# Altium schematic sheet Sheet1.SchDoc(Sheet1)
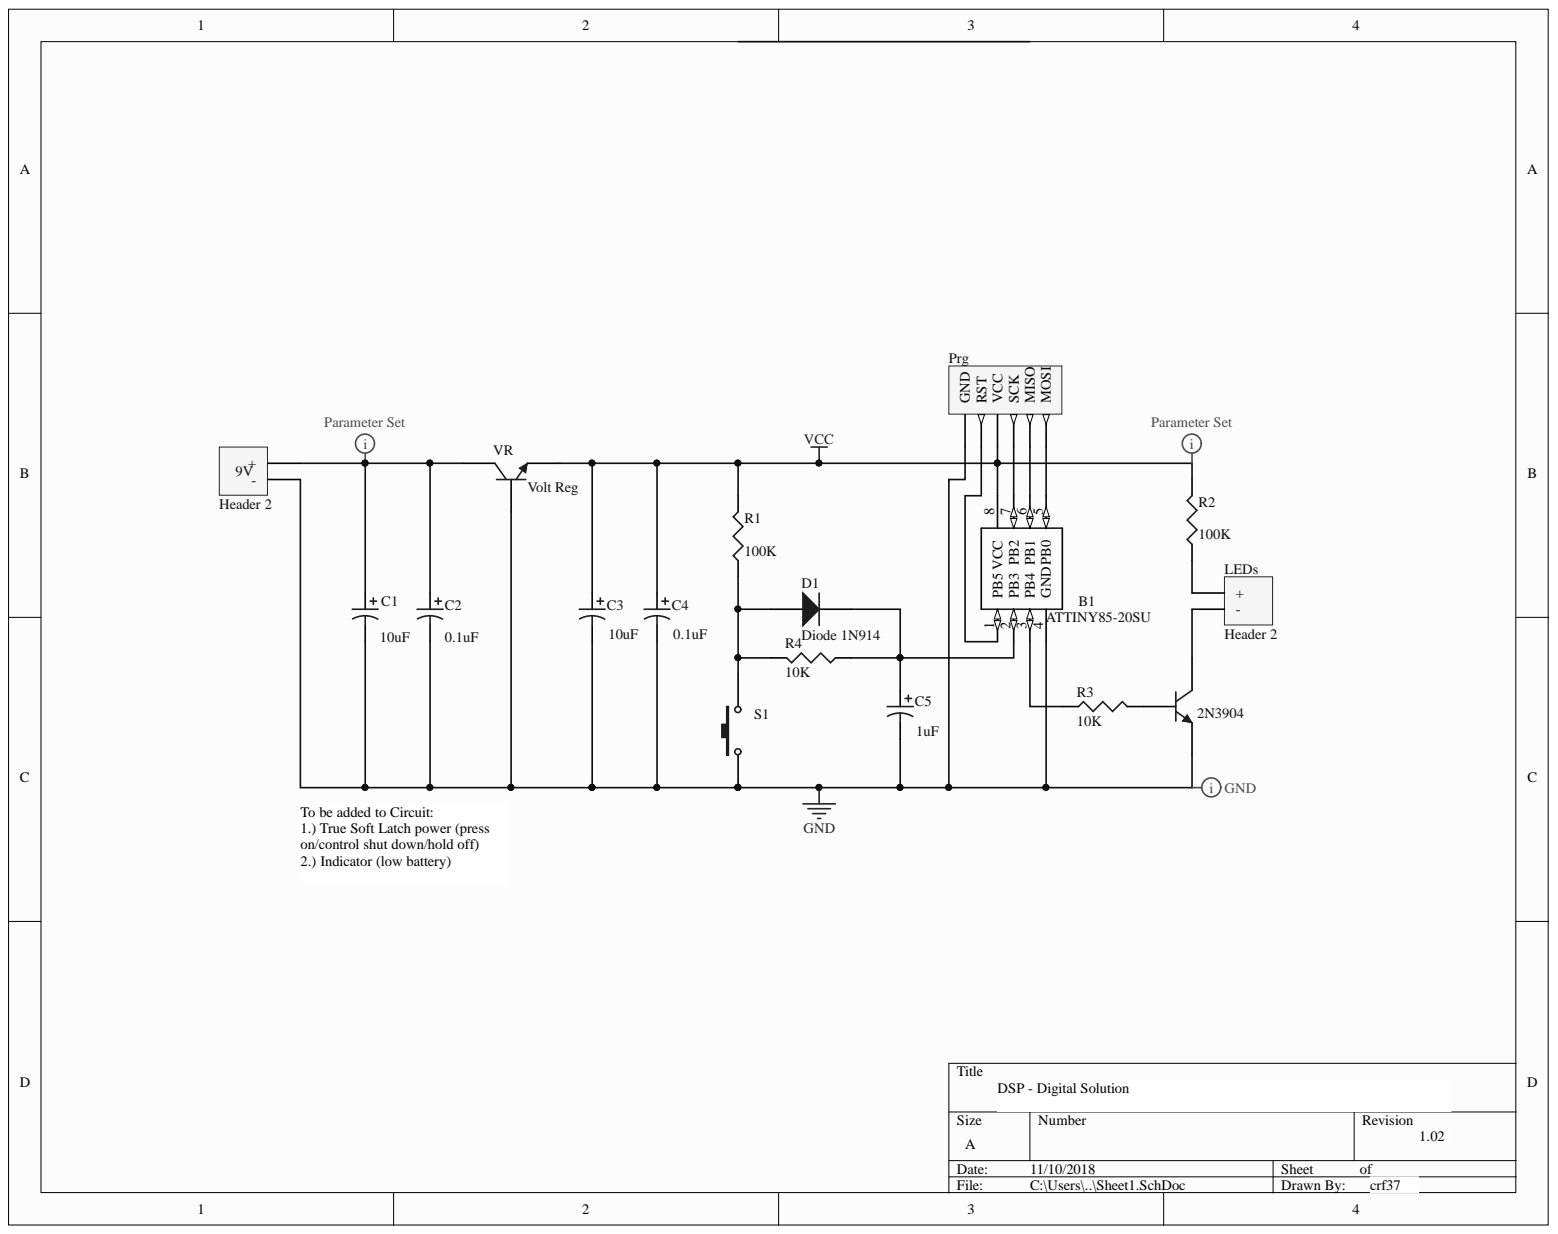
[diagram p0: Sheet1.SchDoc]

## Parts
9V  Header 2  [HDR1X2]
B1  ATTINY85-20SU  [SOT127P798X216-8N]
C1  value 10uF  [C0805]  (hidden comment: Cap Pol3)
C2  value 0.1uF  [C0805]  (hidden comment: Cap Pol3)
C3  value 10uF  [C0805]  (hidden comment: Cap Pol3)
C4  value 0.1uF  [C0805]  (hidden comment: Cap Pol3)
C5  value 1uF  [C0805]  (hidden comment: Cap Pol3)
D1  Diode 1N914  [DO-35]
LEDs  Header 2  [HDR1X2]
Prg  Header 6  [HDR1X6]  (value not drawn)
Q1  2N3904  [TO-92A]
R1  value 100K  [J1-0603]  (hidden comment: Res3)
R2  value 100K  [J1-0603]  (hidden comment: Res3)
R3  value 10K  [J1-0603]  (hidden comment: Res3)
R4  value 10K  [J1-0603]  (hidden comment: Res3)
S1  SW-PB  [SPST-2]  (value not drawn)
VR  Volt Reg  [TO-92A]

## Nets
GND: 9V.1 B1.4(GND) C1.2 C2.2 C3.2 C4.2 C5.2 Prg.1(GND) Q1.1 S1.1 VR.2
VCC: B1.8(VCC) C3.1 C4.1 Prg.3(VCC) R1.2 R2.2 VR.1
Net9V_2: 9V.2 C1.1 C2.1 VR.3
NetB1_1: B1.1(PB5) Prg.2(RST)
NetB1_2: B1.2(PB3) C5.1 D1.2 R4.1
NetB1_3: B1.3(PB4) R3.1
NetB1_5: B1.5(PB0) Prg.6(MOSI)
NetB1_6: B1.6(PB1) Prg.5(MISO)
NetB1_7: B1.7(PB2) Prg.4(SCK)
NetD1_1: D1.1 R1.1 R4.2 S1.2
NetLEDs_1: LEDs.1 R2.2
NetLEDs_2: LEDs.2 Q1.3
NetQ1_2: Q1.2 R3.2
N00002:

## Library data of the parts
9V LEDs = Header 2: Header, 2-Pin; Signal Integrity: Connector; Library Name: Miscellaneous Connectors.IntLib; LatestRevisionNote: Re-released for DXP Platform.; LatestRevisionDate: 17-Jul-2002; Component Kind: Standard; Publisher: Altium Limited
B1 = ATTINY85-20SU: MICROCHIP - ATTINY85-20SU - MICROCONTROLLER MCU, 8 BIT, ATTINY, 20MHZ, SOIC-8; Geometry.Height: 2.16mm; RS Price/Stock: http://uk.rs-online.com/web/p/products/1331674; RS Part Number: 1331674; Manufacturer_Part_Number: ATTINY85-20SU; Manufacturer_Name: Microchip; Library Name: ATTINY85-20SU.SchLib; Datasheet Link: https://componentsearchengine.com/Datasheets/2/ATTINY85-20SU.pdf; Component Kind: Standard; Arrow Price/Stock: https://www.arrow.com/en/products/attiny85-20su/microchip-technology; Arrow Part Number: ATTINY85-20SU
C1-C5 = Cap Pol3: Polarized Capacitor (Surface Mount); Simulation: CAP; Signal Integrity: Cap Pol; Published: 8-Jun-2000; PackageReference: C0805; PackageDescription: Chip Capacitor; Library Name: Miscellaneous Devices.IntLib; LatestRevisionNote: IPC-7351 Footprint Added.; LatestRevisionDate: 29-May-2009; Component Kind: Standard; Publisher: Altium Limited
D1 = Diode 1N914: High Conductance Fast Diode; Simulation: 1N914; Signal Integrity: 1N914; Published: 8-Jun-2000; PackageReference: DO-35; PackageDescription: Diode, Axial; Body 3.8 x 1.9 mm (LxDia. max), Lead Dia. 0.53 mm (max); Library Name: Miscellaneous Devices.IntLib; LatestRevisionNote: Re-released for DXP Platform.; LatestRevisionDate: 17-Jul-2002; DatasheetVersion: 1997; ComponentLink2URL: http://www.fairchildsemi.com/ds/1N%2F1N4448.pdf; ComponentLink2Description: Datasheet; ComponentLink1URL: http://www.fairchildsemi.com/; ComponentLink1Description: Manufacturer Link; Component Kind: Standard; Code_JEDEC: DO-204-AH; Publisher: Altium Limited
Prg = Header 6: Header, 6-Pin; Signal Integrity: Connector; Library Name: Miscellaneous Connectors.IntLib; LatestRevisionNote: Re-released for DXP Platform.; LatestRevisionDate: 17-Jul-2002; Component Kind: Standard; Publisher: Altium Limited
Q1 VR = 2N3904: NPN General Purpose Amplifier; Simulation: 2N3904; Signal Integrity: 2N3904; Published: 8-Jun-2000; PackageVersion: Sep-1998; PackageReference: TO-92A; PackageDescription: TO, Flat Index; 3 In-Line, Axial Leads; Body Dia. 4.5mm; Leads 0.51 x 0.48 mm (max); Library Name: Miscellaneous Devices.IntLib; LatestRevisionNote: PCB Footprint 'BCY-W3/D4.7' replaced; LatestRevisionDate: 15-Jan-2003; DatasheetVersion: 1997; ComponentLink2URL: http://www.fairchildsemi.com/ds/2N%2F2N3904.pdf; ComponentLink2Description: Datasheet; ComponentLink1URL: http://www.fairchildsemi.com/; ComponentLink1Description: Manufacturer Link; Component Kind: Standard; Publisher: Altium Limited
R1-R4 = Res3: Resistor; Simulation: RESISTOR; Signal Integrity: Res; Published: 8-Jun-2000; PackageReference: J1-0603; PackageDescription: Chip Resistor; Library Name: Miscellaneous Devices.IntLib; LatestRevisionNote: IPC-7351 Footprint Added.; LatestRevisionDate: 29-May-2009; Component Kind: Standard; Publisher: Altium Limited
S1 = SW-PB: Switch; Published: 24-Mar-1999; PackageReference: SPST-2; PackageDescription: Switch; 2 Leads; Library Name: Miscellaneous Devices.IntLib; LatestRevisionNote: Re-released for DXP Platform.; LatestRevisionDate: 17-Jul-2002; Component Kind: Standard; Publisher: Altium Limited
